# Altium schematic sheet Sata_SSD2.SchDoc(Sata_SSD2)
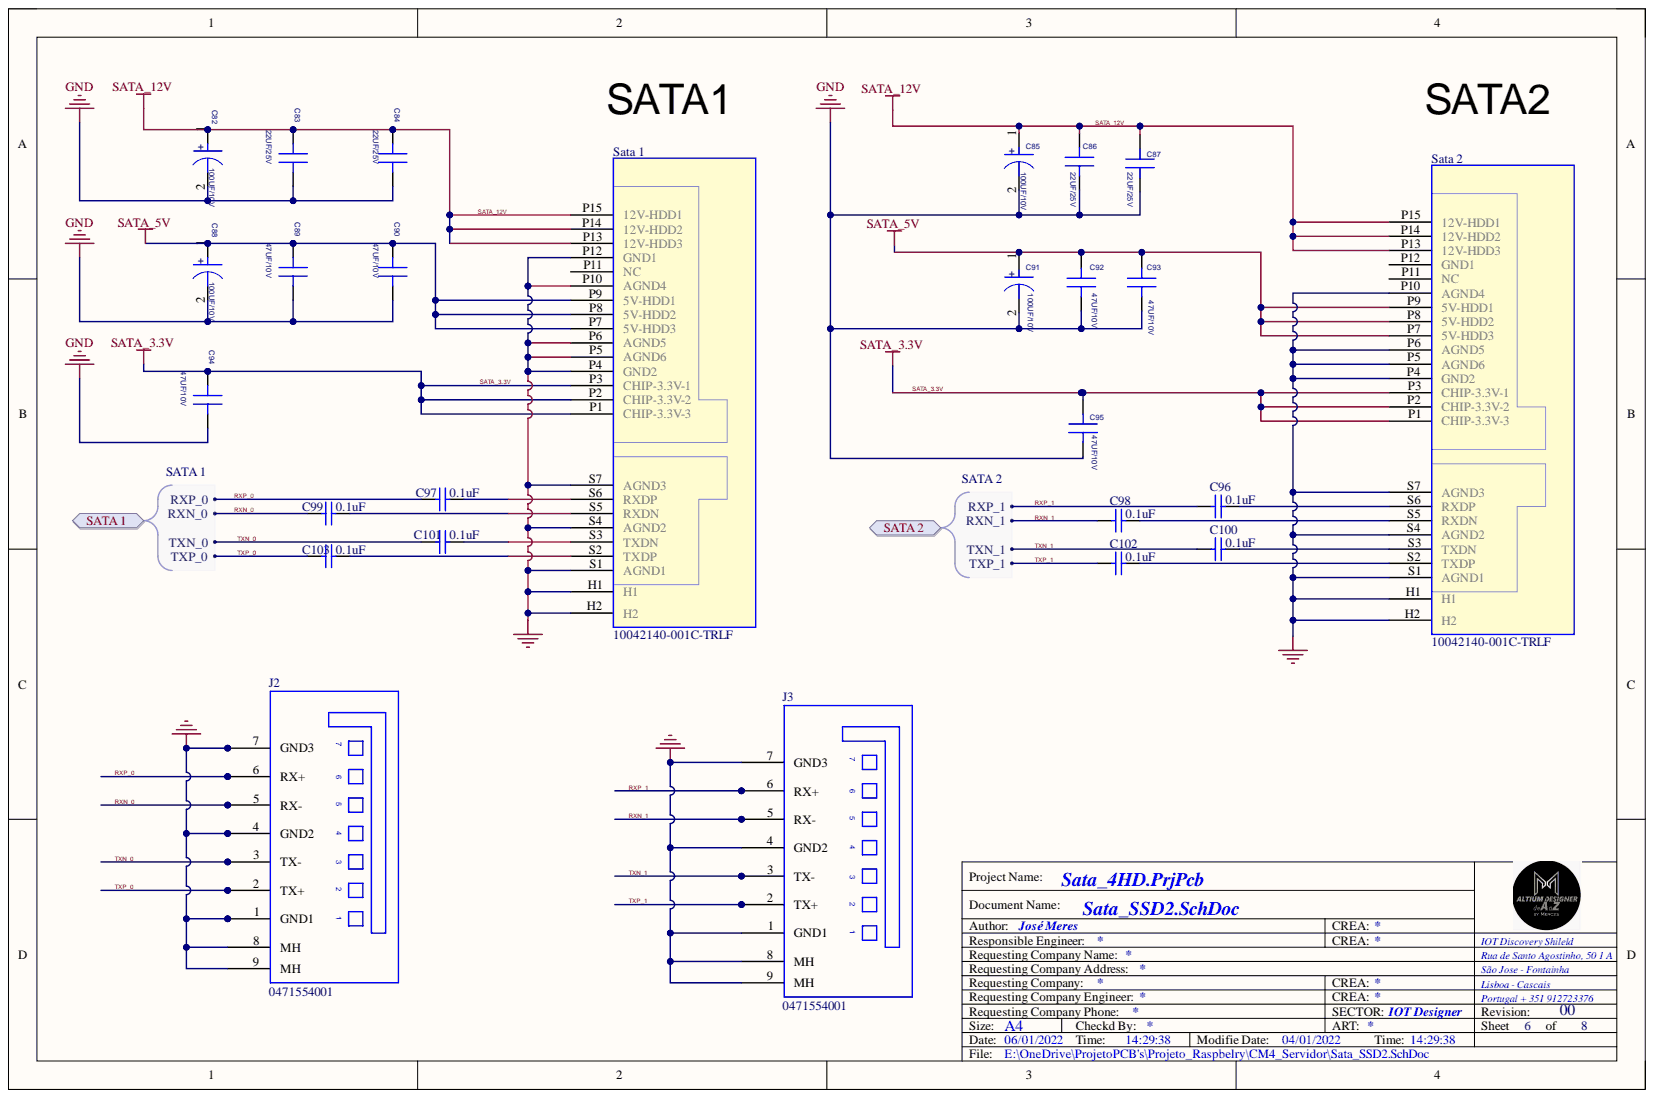
[diagram p0: Sata_SSD2.SchDoc]

## Parts
C82  100UF/10V  [Capacitor SMD Eletrolitic-D8.0x10]
C83  22UF/25V  [C0805-ROUND]
C84  22UF/25V  [C0805-ROUND]
C85  100UF/10V  [Capacitor SMD Eletrolitic-D8.0x10]
C86  22UF/25V  [C0805-ROUND]
C87  22UF/25V  [C0805-ROUND]
C88  100UF/10V  [Capacitor SMD Eletrolitic-D8.0x10]
C89  47UF/10V  [C0805-ROUND]
C90  47UF/10V  [C0805-ROUND]
C91  100UF/10V  [Capacitor SMD Eletrolitic-D8.0x10]
C92  47UF/10V  [C0805-ROUND]
C93  47UF/10V  [C0805-ROUND]
C94  47UF/10V  [C0805-ROUND]
C95  47UF/10V  [C0805-ROUND]
C96  value 0.1uF  [C0201-ROUND]  (hidden comment: GRM155R71A104KA01D)
C97  value 0.1uF  [C0201-ROUND]  (hidden comment: GRM155R71A104KA01D)
C98  value 0.1uF  [C0201-ROUND]  (hidden comment: GRM155R71A104KA01D)
C99  value 0.1uF  [C0201-ROUND]  (hidden comment: GRM155R71A104KA01D)
C100  value 0.1uF  [C0201-ROUND]  (hidden comment: GRM155R71A104KA01D)
C101  value 0.1uF  [C0201-ROUND]  (hidden comment: GRM155R71A104KA01D)
C102  value 0.1uF  [C0201-ROUND]  (hidden comment: GRM155R71A104KA01D)
C103  value 0.1uF  [C0201-ROUND]  (hidden comment: GRM155R71A104KA01D)
J2  0471554001  [SATA_VERTICAL]
J3  0471554001  [SATA_VERTICAL]
Sata_1  10042140-001C-TRLF  [sata_22p_smd_h6_h9]
Sata_2  10042140-001C-TRLF  [sata_22p_smd_h6_h9]

## Ports
SATA 1, SATA 1.RXN_0, SATA 1.RXP_0, SATA 1.TXN_0, SATA 1.TXP_0, SATA 2, SATA 2.RXN_1, SATA 2.RXP_1, SATA 2.TXN_1, SATA 2.TXP_1

## Nets
GND: C82.2 C83.2 C84.2 C85.2 C86.2 C87.2 C88.2 C89.2 C90.2 C91.2 C92.2 C93.2 C94.2 C95.2 J2.1(GND1) J2.4(GND2) J2.7(GND3) J2.8(MH) J2.9(MH) J3.1(GND1) J3.4(GND2) J3.7(GND3) J3.8(MH) J3.9(MH) Sata_1.H1 Sata_1.H2 Sata_1.P4(GND2) Sata_1.P5(AGND6) Sata_1.P6(AGND5) Sata_1.P10(AGND4) Sata_1.P12(GND1) Sata_1.S1(AGND1) Sata_1.S4(AGND2) Sata_1.S7(AGND3) Sata_2.H1 Sata_2.H2 Sata_2.P4(GND2) Sata_2.P5(AGND6) Sata_2.P6(AGND5) Sata_2.P10(AGND4) Sata_2.S1(AGND1) Sata_2.S4(AGND2) Sata_2.S7(AGND3)
SATA_5V: C88.1 C89.1 C90.1 C91.1 C92.1 C93.1 Sata_1.P7(5V-HDD3) Sata_1.P8(5V-HDD2) Sata_1.P9(5V-HDD1) Sata_2.P7(5V-HDD3) Sata_2.P8(5V-HDD2) Sata_2.P9(5V-HDD1)
RXN_0: C99.2 J2.5(RX-) ; port SATA 1
RXN_1: C98.2 J3.5(RX-) ; port SATA 2
RXP_0: C97.2 J2.6(RX+) ; port SATA 1
RXP_1: C96.2 J3.6(RX+) ; port SATA 2
SATA_3.3V: C94.1 C95.1 Sata_1.P1(CHIP-3.3V-3) Sata_1.P2(CHIP-3.3V-2) Sata_1.P3(CHIP-3.3V-1) Sata_2.P1(CHIP-3.3V-3) Sata_2.P2(CHIP-3.3V-2) Sata_2.P3(CHIP-3.3V-1)
SATA_12V: C82.1 C83.1 C84.1 C85.1 C86.1 C87.1 Sata_1.P13(12V-HDD3) Sata_1.P14(12V-HDD2) Sata_1.P15(12V-HDD1) Sata_2.P13(12V-HDD3) Sata_2.P14(12V-HDD2) Sata_2.P15(12V-HDD1)
TXN_0: C101.2 J2.3(TX-) ; port SATA 1
TXN_1: C100.2 J3.3(TX-) ; port SATA 2
TXP_0: C103.2 J2.2(TX+) ; port SATA 1
TXP_1: C102.2 J3.2(TX+) ; port SATA 2
NetC96_1: C96.1 Sata_2.S6(RXDP)
NetC97_1: C97.1 Sata_1.S6(RXDP)
NetC98_1: C98.1 Sata_2.S5(RXDN)
NetC99_1: C99.1 Sata_1.S5(RXDN)
NetC100_1: C100.1 Sata_2.S3(TXDN)
NetC101_1: C101.1 Sata_1.S3(TXDN)
NetC102_1: C102.1 Sata_2.S2(TXDP)
NetC103_1: C103.1 Sata_1.S2(TXDP)

Not connected: Sata_1 P11(NC); Sata_2 P11(NC) P12(GND1)

## Library data of the parts
C82 C85 C88 C91 = CP_0: PARTS: 1; %182%%238%%182%%168%%185%%164%%215%%247%%181%%231%%209%%185%(V): 25; %195%%232%%202%%246%: 非固体SMD铝电容-25V-220UF-+/-20%-8*10.5-8.3*8.3MM-极性-105DEGC-1000H-SMD-温度敏感器件-工艺耐温250DEGC,5S; %208%%161%%192%%224%%195%%251%%179%%198%: 非固体SMD铝电容; CODE: 8120004; COMPONENT_HIGH_MAX: 10.5MM; DEVICE: EMVE250ADA221MHA0G; LEVEL: STD; OriginalSymbolOrigin: (0,30); PCB Footprint: ct7343; PKG_TYPE: SCAPC2-280-327; Component Kind: Standard
C83 C86 C92 C94 C95 = C_0_Dup2: PARTS: 1; %182%%238%%182%%168%%185%%164%%215%%247%%181%%231%%209%%185%(V): 25; %195%%232%%202%%246%: SMD陶瓷电容-25V-22000NF-+/-10%-X7R-1210-所有; %208%%161%%192%%224%%195%%251%%179%%198%: SMD陶瓷电容; CODE: 8070825; COMPONENT_HIGH_MAX: 2.7MM; DEVICE: CETMK325B7226KM_T; LEVEL: STD; OriginalSymbolOrigin: (0,30); PCB Footprint: SC1206; PINSWAP: (A,B); Component Kind: Standard; PKG_TYPE: SC1206
C84 C87 C90 C93 = C_0_Dup2: PARTS: 1; %182%%238%%182%%168%%185%%164%%215%%247%%181%%231%%209%%185%(V): 25; %195%%232%%202%%246%: SMD陶瓷电容-25V-22000NF-+/-10%-X7R-1210-所有; %208%%161%%192%%224%%195%%251%%179%%198%: SMD陶瓷电容; CODE: 8070825; COMPONENT_HIGH_MAX: 2.7MM; DEVICE: CETMK325B7226KM_T; LEVEL: STD; OriginalSymbolOrigin: (0,30); PCB Footprint: SC1206; PINSWAP: (A,B); PKG_TYPE: SC1210; Component Kind: Standard
C89 = C_0_Dup2: PARTS: 1; %182%%238%%182%%168%%185%%164%%215%%247%%181%%231%%209%%185%(V): 25; %195%%232%%202%%246%: SMD陶瓷电容-25V-22000NF-+/-10%-X7R-1210-所有; %208%%161%%192%%224%%195%%251%%179%%198%: SMD陶瓷电容; CODE: 8070825; COMPONENT_HIGH_MAX: 2.7MM; DEVICE: CETMK325B7226KM_TK; LEVEL: STD; OriginalSymbolOrigin: (0,30); PCB Footprint: SC1206; PINSWAP: (A,B); Component Kind: Standard; PKG_TYPE: SC1206
C96-C103 = Capacitor: Chipe CapacitorSMD; Manufacturers: Murata; Kind: 100nF; Precision (%): 10%; Tensão: 10V; Versão: Basica/Completa; Case: SMD - 0201; Component Kind: Standard; Manufacturer P/N: GRM155R71A104KA01D; Published: 30/11/2017; Library Name: Modulos_Xbee.SchLib; Publisher: Merces
J2 J3 = 0471554001: CONN SATA HDR 7POS PCB VERT; Manufacturer: Molex Inc; .Checked PCB: used; Connector Style: Header, Shrouded; Number of Positions: 7; .Checked SCH: used; Category: Connectors, Interconnects; Component Kind: Standard; ComponentLink1Description: http://www.molex.com/elqNow/elqRedir.htm?ref=http://www.molex.com/pdm_docs/sd/471554001_sd.pdf; ComponentLink1URL: http://www.molex.com/elqNow/elqRedir.htm?ref=http://www.molex.com/pdm_docs/sd/471554001_sd.pdf; Connector Type: SATA; Contact Finish: Gold; Contact Finish Thickness: Flash; Features: Board Lock; Manufacturer Part Number: 0471554001; Mounting Type: Through Hole; Packaging: Tray; Pricing 1: 1=1.37, 10=1.065, 25=0.9928, 50=0.9026, 100=0.8123, 250=0.68592, 500=0.6498, 1000=0.5776, 2500=0.48013 (USD); RoHS: RoHS Compliant; Stock 1: 8065; Supplier 1: Digi-Key; Supplier Part Number 1: WM4059-ND; Termination: Solder; Library Name: Merces_Library.SchLib
Sata_1 Sata_2 = SATA 5+12P: Component Kind: Standard; PCB Footprint: sata_22p_smd_h6_h9; Library Name: Merces_Library.SchLib
